# Altium schematic sheet Carte_OPB.SchDoc(Carte_OPB)
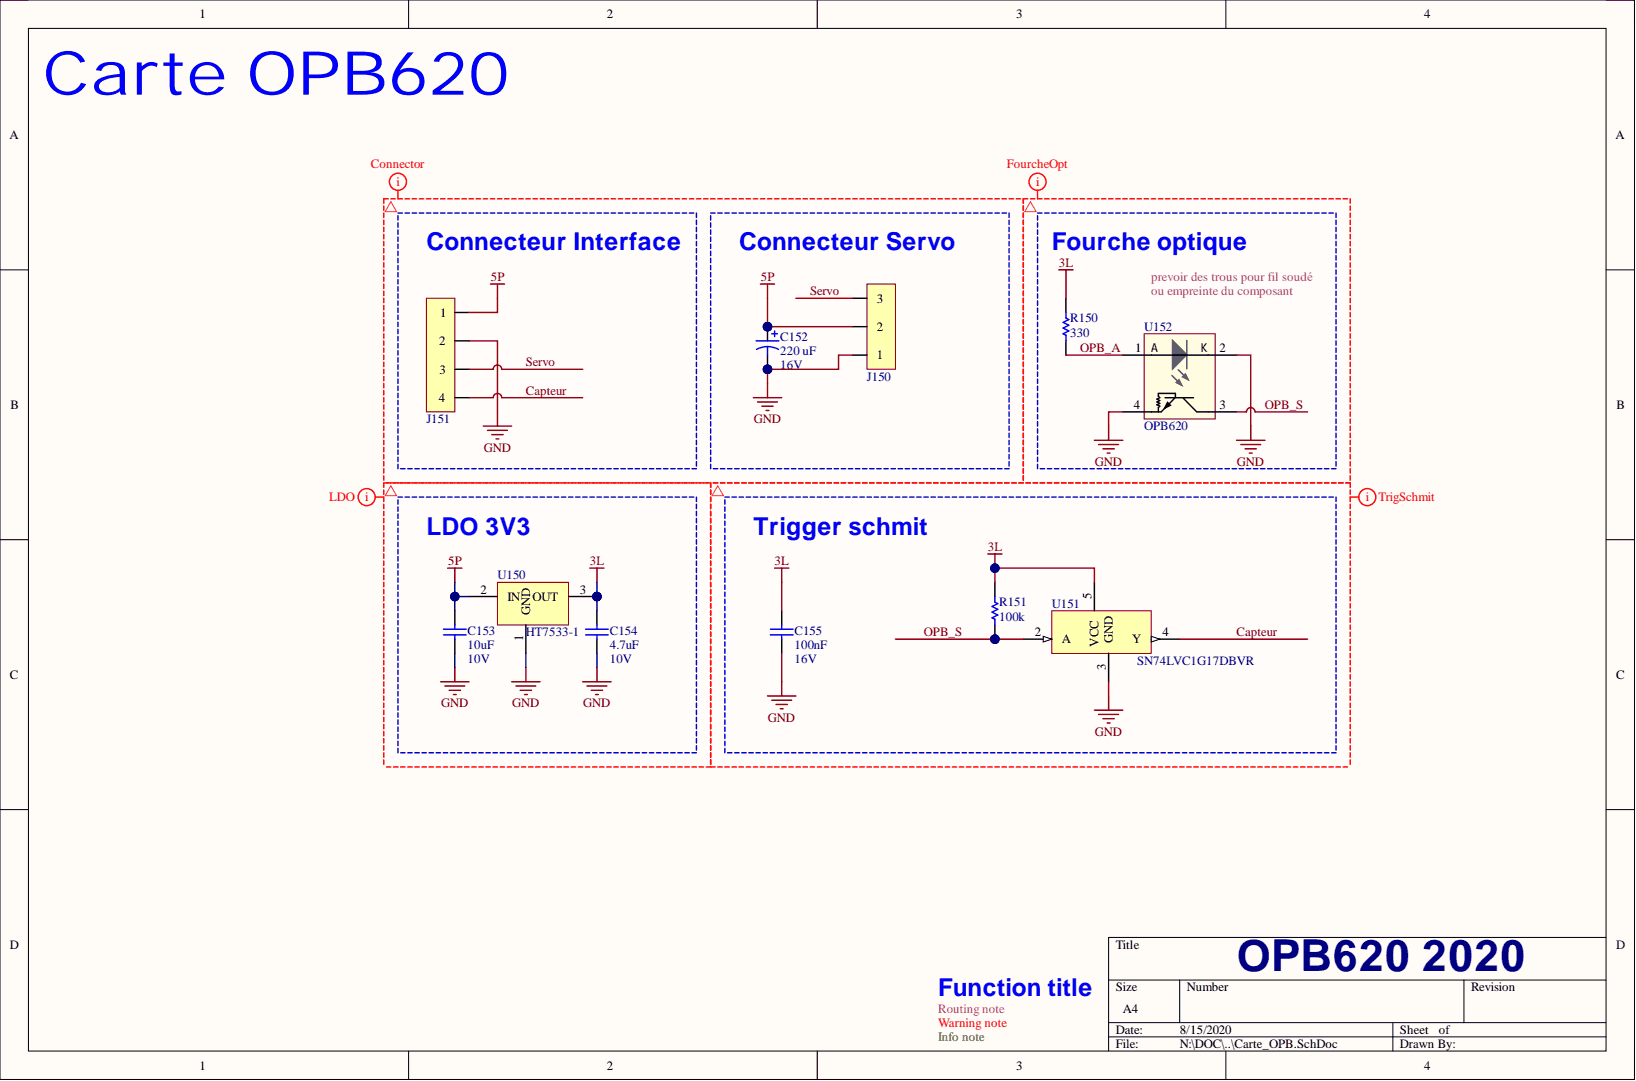
[diagram p0: Carte_OPB.SchDoc]

## Parts
C152  220 uF  RatedVoltage: 16V  [WCAP-ATG8_6.3x11x2.5x0.5]
C153  10uF  RatedVoltage: 10V  [WCAP-CSGP_0805, 2x1.25x1.25]
C154  4.7uF  RatedVoltage: 10V  [WCAP-CSGP_0603, 1.6x0.8x0.8]
C155  100nF  RatedVoltage: 16V  [WCAP-CSGP_0402, 1x0.5x0.5]
J150  22-03-2031  [22-03-2031]  (value not drawn)
J151  22-03-2041  [22-03-2041]  (value not drawn)
R150  value 330  [RESC1005X04N]  (hidden comment: RC0402FR-07330RL)
R151  value 100k  [RESC1005X04N]  (hidden comment: RC0402FR-07100KL)
U150  HT7533-1  [SOT89-150P350X160-3N]
U151  SN74LVC1G17DBVR  [SOT23_95P280X145-5N]
U152  OPB620  [OPB620]

## Nets
3L: C154.1 C155.1 R150.1 R151.2 U150.3 U151.5(VCC)
5P: C152.1 C153.1 J150.2 J151.1 U150.2
GND: C152.2 C153.2 C154.2 C155.2 J150.1 J151.2 U150.1 U151.3(GND) U152.2 U152.4
Capteur: J151.4 U151.4(Y)
OPB_A: R150.2 U152.1
OPB_S: R151.1 U151.2(A) U152.3
Servo: J150.3 J151.3

## Library data of the parts
C152 = WCAP-ATG8, 860010373010: CAP Alu 220 UF 20% 16 V; Manufacturer: Wurth Elektronik; Component Kind: Standard; ComponentLink1Description: Datasheet; ComponentLink1URL: http://katalog.we-online.de/pbs/datasheet/860010373010.pdf; Manufacturer Part Number: 860010373010; Size: 6.3mmx11mm; Value: 220 uF; Library Name: Condensateur.IntLib
C153 = WCAP-CSGP, 885012207026: CAP CER 10uF 10V X7R 0805; Manufacturer: Wurth Elektronik; CeramicType: X7R; Component Kind: Standard; ComponentLink1Description: Datasheet; ComponentLink1URL: http://katalog.we-online.com/pbs/datasheet/885012207026.pdf; Manufacturer Part Number: 885012207026; Size: 0805; Supplier 1: Farnell; Supplier 2: JLCPCB; Supplier Footprint 2: 0805; Supplier Part Number 1: 2495189; Supplier Part Number 2: C15850; Value: 10uF; Library Name: Condensateur.IntLib
C154 = WCAP-CSGP, 885012106012: CAP CER 4.7UF 10V X5R 0603; Manufacturer: Wurth Elektronik; CeramicType: X5R; Component Kind: Standard; ComponentLink1Description: Datasheet; ComponentLink1URL: http://katalog.we-online.com/pbs/datasheet/885012106012.pdf; Manufacturer Part Number: 885012106012; Size: 0603; Supplier 2: JLCPCB; Supplier Footprint 2: 0603; Supplier Part Number 2: C19666; Value: 4.7uF; Library Name: Condensateur.IntLib
C155 = WCAP-CSGP, 885012205037: CAP CER 100nF 16V X7R 0402; Manufacturer: Wurth Elektronik; CeramicType: X7R; Component Kind: Standard; ComponentLink1Description: Datasheet; ComponentLink1URL: http://katalog.we-online.com/pbs/datasheet/885012205037.pdf; Manufacturer Part Number: 885012205037; Size: 0402; Supplier 2: JLCPCB; Supplier Footprint 2: 0402; Supplier Part Number 2: C1525; Value: 100nF; Library Name: Condensateur.IntLib
J150 = 22-03-2031: CONN HEADER VERT 3POS 2.54MM; Manufacturer: MOLEX; Component Kind: Standard; ComponentLink1Description: Datasheet; ComponentLink1URL: http://www.farnell.com/datasheets/1785732.pdf; ComponentLink2Description: Drawings; ComponentLink2URL: http://www.farnell.com/cad/495071.pdf  http://www.farnell.com/cad/1533907.pdf  http://www.farnell.com/cad/1533907.pdf; Manufacturer Part Number: 22-03-2031; Supplier 1: Farnell; Supplier Part Number 1: 9731083; Library Name: Connecteurs.IntLib
J151 = 22-03-2041: CONN HEADER VERT 4POS 2.54MM; Manufacturer: MOLEX; Component Kind: Standard; ComponentLink1Description: Datasheet; ComponentLink1URL: https://www.molex.com/pdm_docs/sd/022032041_sd.pdf; Manufacturer Part Number: 22-03-2041; Supplier 1: Farnell; Supplier Part Number 1: 9731091; Library Name: Connecteurs.IntLib
R150 = RC0402FR-07330RL: RES SMD 330 OHM 1% 1/16W 0402; Manufacturer: Yageo; Power: 63mW; Vmax: 50V; Component Kind: Standard; ComponentLink1Description: Manufacturer; ComponentLink1URL: http://www.yageo.com/NewPortal/_en/index.jsp; ComponentLink2Description: Datasheet; ComponentLink2URL: http://www.farnell.com/datasheets/2167052.pdf; Manufacturer Part Number: RC0402FR-07330RL; Precision: ±1%; Supplier 1: Farnell; Supplier 2: JLCPCB; Supplier Footprint 2: 0402; Supplier Part Number 1: 9239170; Supplier Part Number 2: C25104; Type: Thick Film; Library Name: Resistance.IntLib
R151 = RC0402FR-07100KL: RES SMD 100K OHM 1% 1/16W 0402; Manufacturer: Yageo; Power: 63mW; Vmax: 50V; Component Kind: Standard; ComponentLink1Description: Manufacturer; ComponentLink1URL: http://www.yageo.com/NewPortal/_en/index.jsp; ComponentLink2Description: Datasheet; ComponentLink2URL: http://www.farnell.com/datasheets/2167052.pdf; Manufacturer Part Number: RC0402FR-07100KL; Precision: ±1%; Supplier 1: Farnell; Supplier 2: JLCPCB; Supplier Footprint 2: 0402; Supplier Part Number 1: 9239472; Supplier Part Number 2: C25741; Type: Thick Film; Library Name: Resistance.IntLib
U150 = HT7533-1: LDO 3.3V 100mA SOT89; Manufacturer: Holtek; Component Kind: Standard; ComponentLink1Description: Datasheet; ComponentLink1URL: https://datasheet.lcsc.com/szlcsc/1812071811_Holtek-Semicon-HT7533-1_C14289.pdf; ComponentLink2Description: Manufacturer; ComponentLink2URL: https://www.holtek.com/productdetail/-/vg/75xx_1; Manufacturer Part Number: HT7533-1; Supplier 1: RSComponents; Supplier 2: JLCPCB; Supplier Footprint 2: SOT-89-3; Supplier Part Number 1: 8850721; Supplier Part Number 2: C14289; Type: LDO; Value: 3.3V; Library Name: Alimentation.IntLib
U151 = SN74LVC1G17DBVR: IC BUF NON-INVERT 5.5V SOT23-5; Manufacturer: TEXAS INSTRUMENTS; Component Kind: Standard; ComponentLink1Description: Datasheet; ComponentLink1URL: https://www.ti.com/lit/ds/symlink/sn74lvc1g17.pdf?ts=1590614797325; Manufacturer Part Number: SN74LVC1G17DBVR; Supplier 1: Farnell; Supplier Part Number 1: 3119606; Library Name: CI.IntLib
U152 = OPB620: SENSOR OPT SLOT TRANS W/RES PCB; Manufacturer: TT ELECTRONICS; Component Kind: Standard; ComponentLink1Description: Datasheet; ComponentLink1URL: http://www.farnell.com/datasheets/2702441.pdf; Library Name: Opto.IntLib; Manufacturer Part Number: OPB620; Supplier 1: Farnell; Supplier Part Number 1: 491330
